# Altium schematic sheet hri_hand_v2.SchDoc
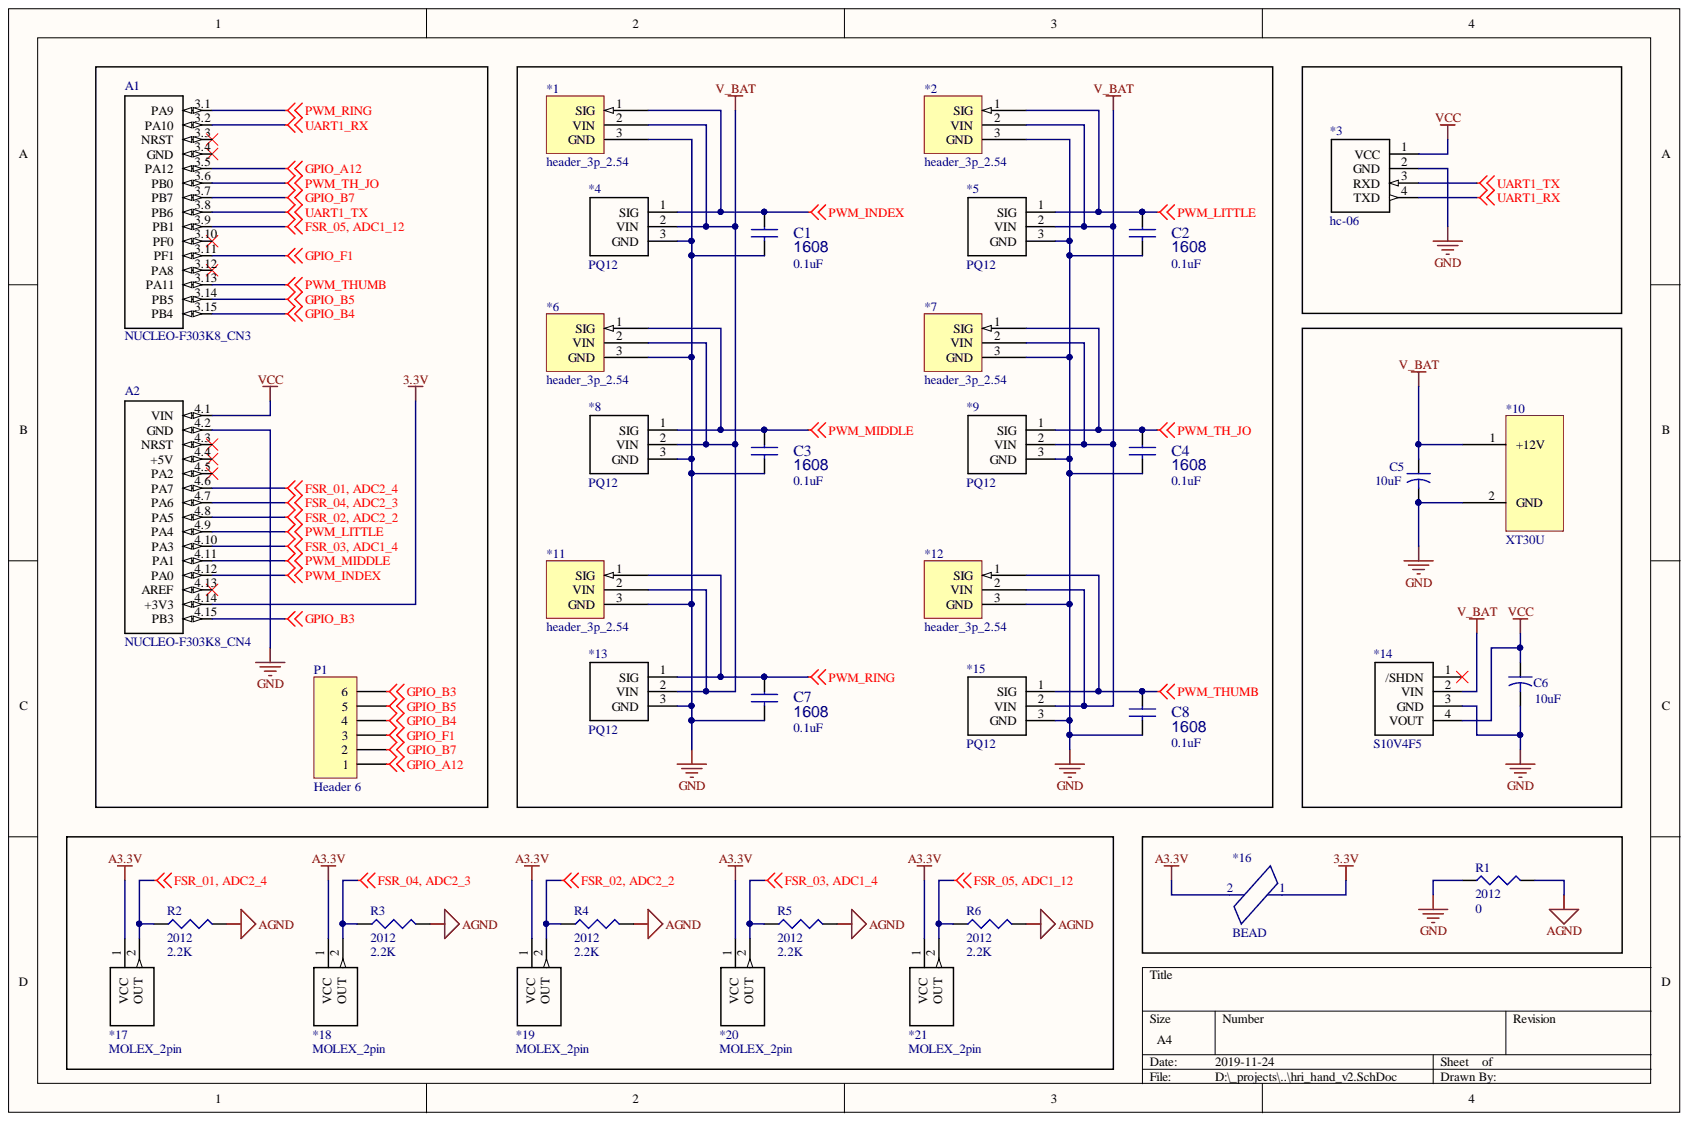
[diagram p0: hri_hand_v2.SchDoc]

## Parts
*1  header_3p_2.54  [header_3x1_2.54]
*2  header_3p_2.54  [header_3x1_2.54]
*3  hc-06  [header_4x1_2.54]
*4  PQ12  [molex_3p_2.54]
*5  PQ12  [molex_3p_2.54]
*6  header_3p_2.54  [header_3x1_2.54]
*7  header_3p_2.54  [header_3x1_2.54]
*8  PQ12  [molex_3p_2.54]
*9  PQ12  [molex_3p_2.54]
*10  XT30U  [XT30F]
*11  header_3p_2.54  [header_3x1_2.54]
*12  header_3p_2.54  [header_3x1_2.54]
*13  PQ12  [molex_3p_2.54]
*14  S10V4F5  [header_4x1_2.54]
*15  PQ12  [molex_3p_2.54]
*16  BEAD  [L-1608-SS]
*17  MOLEX_2pin  [molex_2p_2.54]
*18  MOLEX_2pin  [molex_2p_2.54]
*19  MOLEX_2pin  [molex_2p_2.54]
*20  MOLEX_2pin  [molex_2p_2.54]
*21  MOLEX_2pin  [molex_2p_2.54]
A1  NUCLEO-F303K8_CN3  [header_1*15_3]
A2  NUCLEO-F303K8_CN4  [header_1*15_4]
C1  1608  value 0.1uF  [CAP-1608-SS]
C2  1608  value 0.1uF  [CAP-1608-SS]
C3  1608  value 0.1uF  [CAP-1608-SS]
C4  1608  value 0.1uF  [CAP-1608-SS]
C5  value 10uF  [C-10UF/16V]
C6  value 10uF  [C-10UF/16V]
C7  1608  value 0.1uF  [CAP-1608-SS]
C8  1608  value 0.1uF  [CAP-1608-SS]
P1  Header 6  [HDR1X6]
R1  2012  value 0  [RES-2012]
R2  2012  value 2.2K  [RES-2012]
R3  2012  value 2.2K  [RES-2012]
R4  2012  value 2.2K  [RES-2012]
R5  2012  value 2.2K  [RES-2012]
R6  2012  value 2.2K  [RES-2012]

## Nets
3.3V: *16.1 A2.4.14(+3V3)
A3.3V: *16.2 *17.1 *18.1 *19.1 *20.1 *21.1
AGND: R1.2 R2.2 R3.2 R4.2 R5.2 R6.2
FSR_01, ADC2_4: *17.2 A2.4.6(PA7) R2.1
FSR_02, ADC2_2: *19.2 A2.4.8(PA5) R4.1
FSR_03, ADC1_4: *20.2 A2.4.10(PA3) R5.1
FSR_04, ADC2_3: *18.2 A2.4.7(PA6) R3.1
FSR_05, ADC1_12: *21.2 A1.3.9(PB1) R6.1
GND: *1.3 *2.3 *3.2(GND) *4.3 *5.3 *6.3 *7.3 *8.3 *9.3 *10.2 *11.3 *12.3 *13.3 *14.3(GND) *15.3 A2.4.2(GND) C1.2 C2.2 C3.2 C4.2 C5.2 C6.2 C7.2 C8.2 R1.1
GPIO_A12: A1.3.5(PA12) P1.1
GPIO_B3: A2.4.15(PB3) P1.6
GPIO_B4: A1.3.15(PB4) P1.4
GPIO_B5: A1.3.14(PB5) P1.5
GPIO_B7: A1.3.7(PB7) P1.2
GPIO_F1: A1.3.11(PF1) P1.3
PWM_INDEX: *1.1 *4.1 A2.4.12(PA0) C1.1
PWM_LITTLE: *2.1 *5.1 A2.4.9(PA4) C2.1
PWM_MIDDLE: *6.1 *8.1 A2.4.11(PA1) C3.1
PWM_RING: *11.1 *13.1 A1.3.1(PA9) C7.1
PWM_TH_JO: *7.1 *9.1 A1.3.6(PB0) C4.1
PWM_THUMB: *12.1 *15.1 A1.3.13(PA11) C8.1
UART1_RX: *3.4(TXD) A1.3.2(PA10)
UART1_TX: *3.3(RXD) A1.3.8(PB6)
V_BAT: *1.2 *2.2 *4.2 *5.2 *6.2 *7.2 *8.2 *9.2 *10.1 *11.2 *12.2 *13.2 *14.2(VIN) *15.2 C5.1
VCC: *3.1(VCC) *14.4(VOUT) A2.4.1(VIN) C6.1

Not connected: *14 1(/SHDN); A1 3.3(NRST) 3.4(GND) 3.10(PF0) 3.12(PA8); A2 4.3(NRST) 4.4(+5V) 4.5(PA2) 4.13(AREF)

## Library data of the parts
A1 = NUCLEO-F303K8_CN3: STM32 Nucleo-32 development board with STM32F303K8T6 MCU, supports Arduino connectivity; MF: STMicroelectronics; Component Kind: Standard; Digi-Key_Part_Number: 497-15981-ND; Digi-Key_Purchase_URL: https://www.digikey.kr/product-detail/en/stmicroelectronics/NUCLEO-F303K8/497-15981-ND/5428805?utm_source=snapeda&utm_medium=aggregator&utm_campaign=symbol; MP: NUCLEO-F303K8; Package: None; SnapEDA_Link: https://www.snapeda.com/parts/NUCLEO-F303K8/STMicroelectronics/view-part/?ref=snap; Library Name: hri_hand.SchLib
A2 = NUCLEO-F303K8_CN4: STM32 Nucleo-32 development board with STM32F303K8T6 MCU, supports Arduino connectivity; MF: STMicroelectronics; Component Kind: Standard; Digi-Key_Part_Number: 497-15981-ND; Digi-Key_Purchase_URL: https://www.digikey.kr/product-detail/en/stmicroelectronics/NUCLEO-F303K8/497-15981-ND/5428805?utm_source=snapeda&utm_medium=aggregator&utm_campaign=symbol; MP: NUCLEO-F303K8; Package: None; SnapEDA_Link: https://www.snapeda.com/parts/NUCLEO-F303K8/STMicroelectronics/view-part/?ref=snap; Library Name: hri_hand.SchLib
C1-C4 C7 C8 = CAP NP: Component Kind: Standard
C5 C6 = Cap2: Capacitor; Component Kind: Standard; Library Name: Miscellaneous Devices.IntLib; PackageDescription: Capacitor; 2 Leads; PackageReference: CAPR5-4X5; Published: 23-Sep-2002; Signal Integrity: Cap; Simulation: CAP; Publisher: Altium Limited
P1 = Header 6: Header, 6-Pin; Component Kind: Standard; LatestRevisionDate: 17-Jul-2002; LatestRevisionNote: Re-released for DXP Platform.; Library Name: Miscellaneous Connectors.IntLib; Signal Integrity: Connector; Publisher: Altium Limited
R1 R2 = Res3: Resistor; Component Kind: Standard; LatestRevisionDate: 29-May-2009; LatestRevisionNote: IPC-7351 Footprint Added.; Library Name: Miscellaneous Devices.IntLib; PackageDescription: Chip Resistor; PackageReference: J1-0603; Published: 8-Jun-2000; Signal Integrity: Res; Simulation: RESISTOR; Publisher: Altium Limited
R3-R6 = Res3: Resistor; Value: 2.2K; Component Kind: Standard; LatestRevisionDate: 29-May-2009; LatestRevisionNote: IPC-7351 Footprint Added.; Library Name: Miscellaneous Devices.IntLib; PackageDescription: Chip Resistor; PackageReference: J1-0603; Published: 8-Jun-2000; Signal Integrity: Res; Simulation: RESISTOR; Publisher: Altium Limited
*1 *2 *6 *7 *11 *12 = header_3p_2.54: Component Kind: Standard; Library Name: hri_hand.SchLib
*3 = hc-06: Component Kind: Standard; Library Name: hri_hand.SchLib
*4 *5 *8 *9 *13 *15 = PQ12: Component Kind: Standard; Library Name: hri_hand.SchLib
*10 = XT30U: Component Kind: Standard; Library Name: hri_hand.SchLib
*14 = S10V4F5: Component Kind: Standard; Library Name: hri_hand.SchLib
*16 = BEAD: Component Kind: Standard; Library Name: hri_hand.SchLib
*17-*21 = MOLEX_2pin: Component Kind: Standard; Library Name: hri_hand.SchLib
